# Altium schematic sheet SPI_Breakout.SchDoc
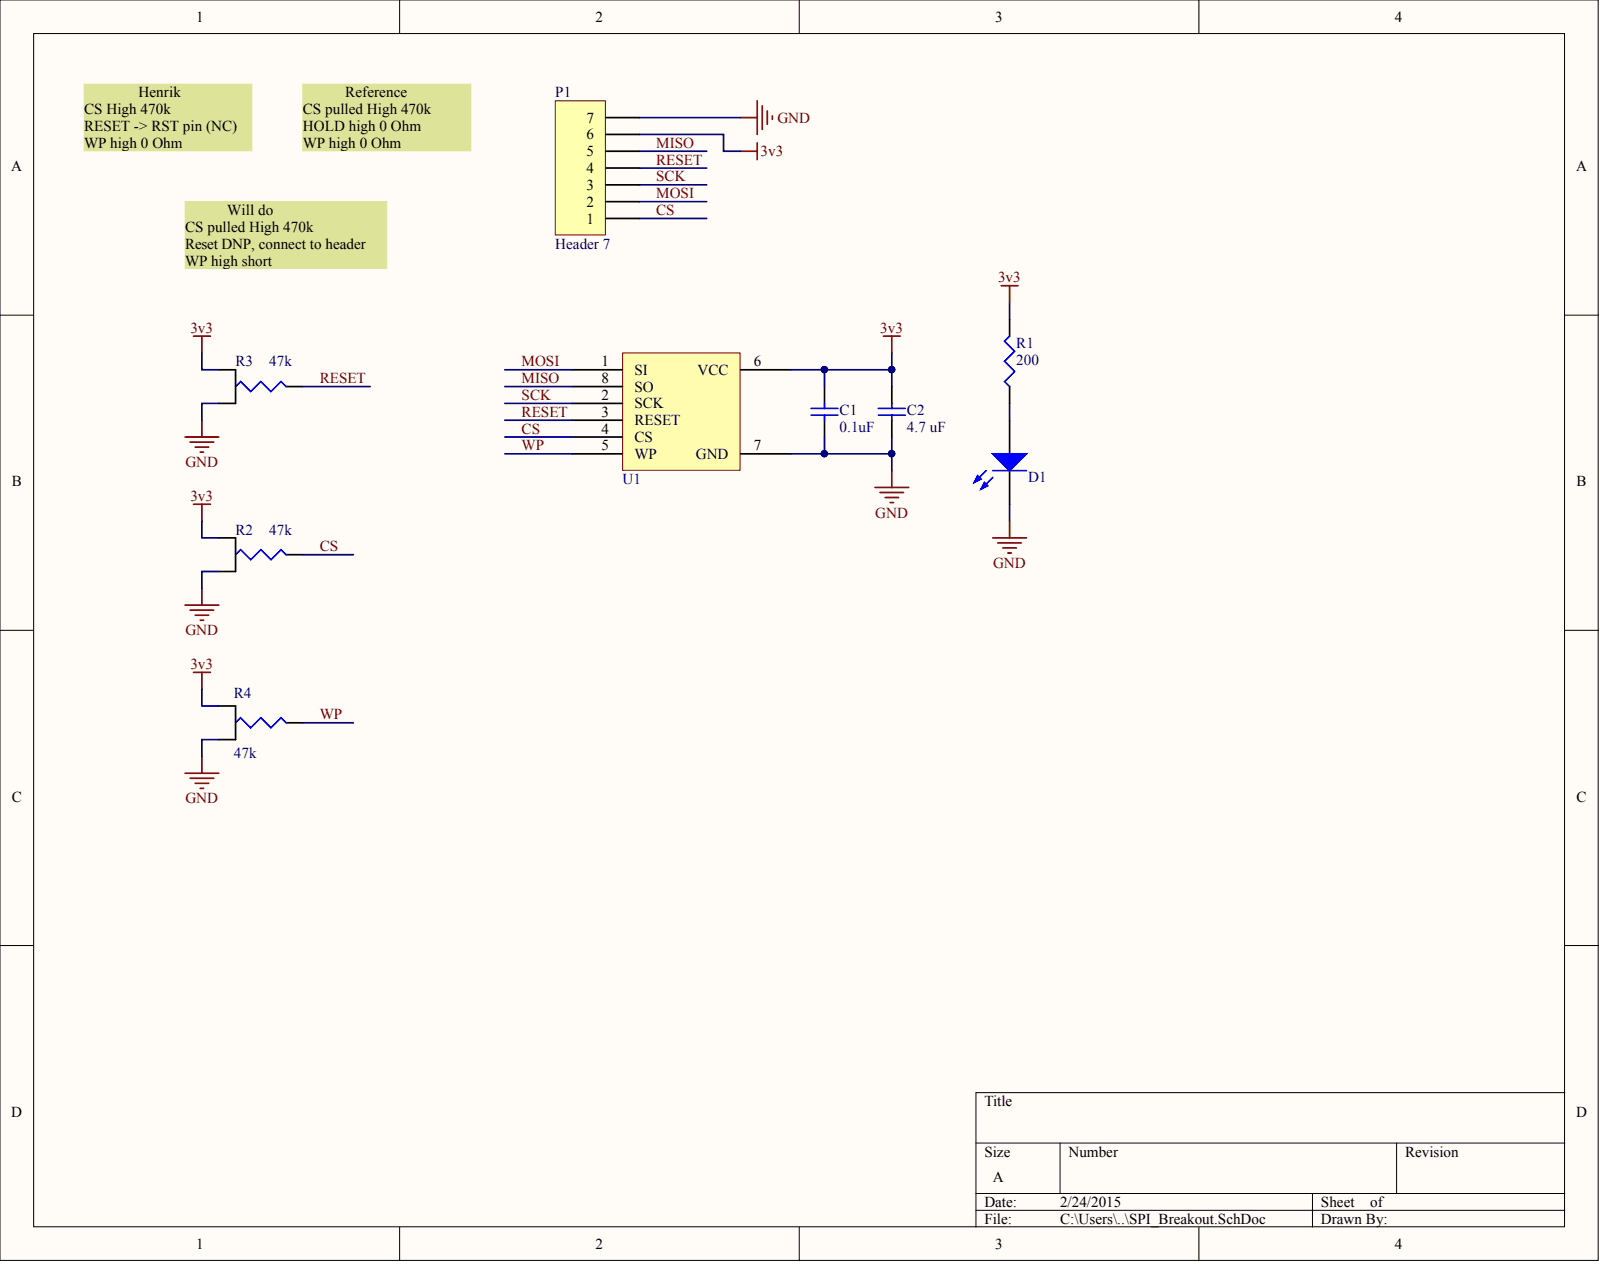
[diagram p0: SPI_Breakout.SchDoc]

## Parts
C1  value 0.1uF  [0402]  (hidden comment: CL05B104KQ5NNNC)
C2  value 4.7 uF  [1608[0603]]  (hidden comment: CL10B475KQ8NQNC)
D1  LSQ976-Z  [LSQ976-Z]  (value not drawn)
P1  Header 7  [HDR1X7]
R1  value 200  [J1-0603]  (hidden comment: MCR03ERTJ201)
R2  value 47k  [0603 Resistor Selectable]  (hidden comment: MCR03ERTF4702_SPDT)
R3  value 47k  [0603 Resistor Selectable]  (hidden comment: MCR03ERTF4702_SPDT)
R4  value 47k  [0603 Resistor Selectable]  (hidden comment: MCR03ERTF4702_SPDT)
U1  AT45DB321E-SHF-B  [SOIC127P600X175-8N]  (value not drawn)

## Nets
3V3: C1.2 C2.2 P1.6 R1.1 R2.1 R3.1 R4.1 U1.6(VCC)
GND: C1.1 C2.1 D1.C P1.7 R2.3 R3.3 R4.3 U1.7(GND)
CS: P1.1 R2.2 U1.4(CS)
MISO: P1.5 U1.8(SO)
MOSI: P1.2 U1.1(SI)
RESET: P1.4 R3.2 U1.3(RESET)
SCK: P1.3 U1.2(SCK)
WP: R4.2 U1.5(WP)
NetD1_A: D1.A R1.2

## Library data of the parts
C1 = CL05B104KQ5NNNC: Cap Ceramic 0.1uF 6.3V X7R 10% SMD 0402; Component Kind: Standard; LatestRevisionDate: 29-May-2009; LatestRevisionNote: IPC-7351 Footprint Added.; PackageDescription: Chip Capacitor; PackageReference: C1206; Published: 8-Jun-2000; Signal Integrity: Cap Semi; Simulation: CAP; Supplier 1: Arrow; Supplier 2: Digi-Key; Supplier Part Number 1: c0Ft5huTF1PnwzXLA59QPYxRs3E3aghew1LSAii7cAMZVywxIBNyoAQstvnyfZZ0; Supplier Part Number 2: 1276-1511-1-ND; Library Name: Linux_Board_SCHEM_LIB.SchLib; Publisher: Altium Limited
C2 = CL10B475KQ8NQNC: CAP CER 4.7UF 6.3V 10% X7R 0603; Component Kind: Standard; LatestRevisionDate: 29-May-2009; LatestRevisionNote: IPC-7351 Footprint Added.; PackageDescription: Chip Capacitor; PackageReference: C1206; Published: 8-Jun-2000; Signal Integrity: Cap Semi; Simulation: CAP; Supplier 1: Digi-Key; Supplier Part Number 1: 1276-2087-1-ND; Library Name: Linux_Board_SCHEM_LIB.SchLib; Publisher: Altium Limited
D1 = LSQ976-Z: OSRAM - LED, SMD, RED, 180MCD, 633NM; Component Kind: Standard; Signal Integrity: Guessed Model; Supplier 1: Mouser; Supplier 2: Newark; Supplier Part Number 1: N/A; Supplier Part Number 2: 05M0541; Library Name: Linux_Board_SCHEM_LIB.SchLib
P1 = Header 7: Header, 7-Pin; Component Kind: Standard; LatestRevisionDate: 17-Jul-2002; LatestRevisionNote: Re-released for DXP Platform.; Library Name: Miscellaneous Connectors.IntLib; Signal Integrity: Connector; Publisher: Altium Limited
R1 = MCR03ERTJ201: RES 200 OHM 1/10W 5% 0603 SMD; Component Kind: Standard; LatestRevisionDate: 29-May-2009; LatestRevisionNote: IPC-7351 Footprint Added.; PackageDescription: Chip Resistor; PackageReference: J1-0603; Published: 8-Jun-2000; Signal Integrity: Res; Simulation: RESISTOR; Supplier 1: Digi-Key; Supplier Part Number 1: RHM200CGCT-ND; Library Name: Linux_Board_SCHEM_LIB.SchLib; Publisher: Altium Limited
R2-R4 = MCR03ERTF4702_SPDT: RES SMD 47K OHM 1% 1/10W 0603 selectable SPDT; Component Kind: Standard; LatestRevisionDate: 29-May-2009; LatestRevisionNote: IPC-7351 Footprint Added.; PackageDescription: Chip Resistor; PackageReference: J1-0603; Published: 8-Jun-2000; Signal Integrity: Res; Simulation: RESISTOR; Supplier 1: Digi-Key; Supplier Part Number 1: RHM47.0KCFCT-ND; Library Name: Linux_Board_SCHEM_LIB.SchLib; Publisher: Altium Limited
U1 = AT45DB321E-SHF-B: DATAFLASH 32MBIT 85MHZ 8SOIC; Component Kind: Standard; Supplier 1: Digi-Key; Supplier Part Number 1: 1265-1072-5-ND; Library Name: Linux_Board_SCHEM_LIB.SchLib
